# Altium schematic sheet Power_Board_R1.SchDoc
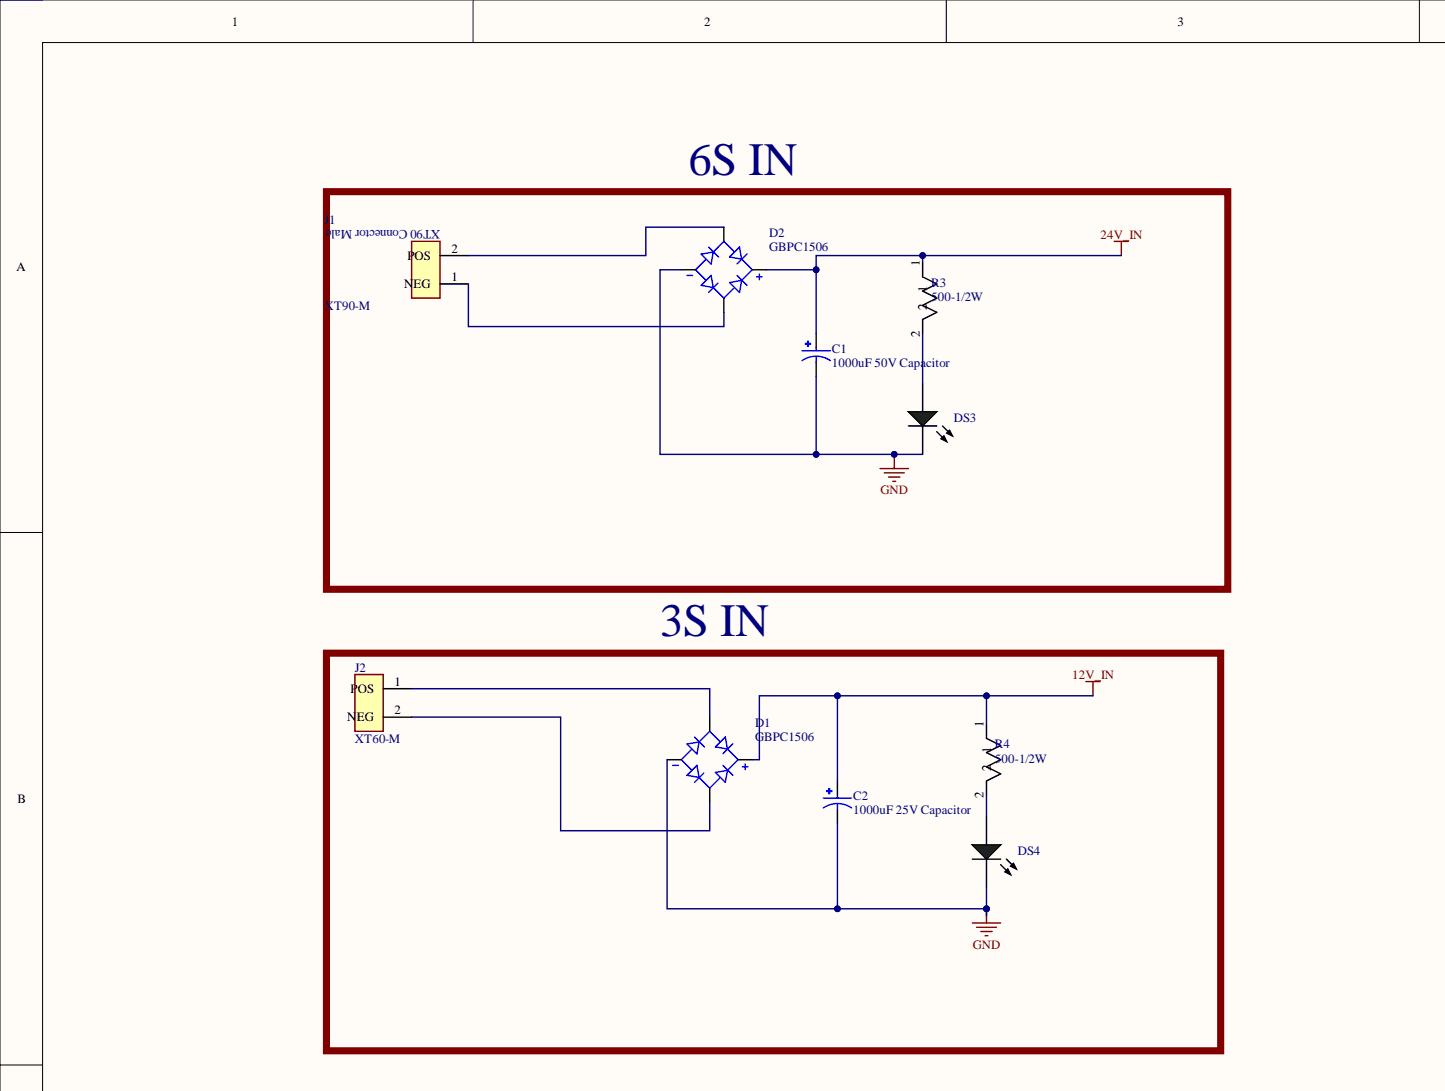
[diagram p0: Power_Board_R1.SchDoc, top left]
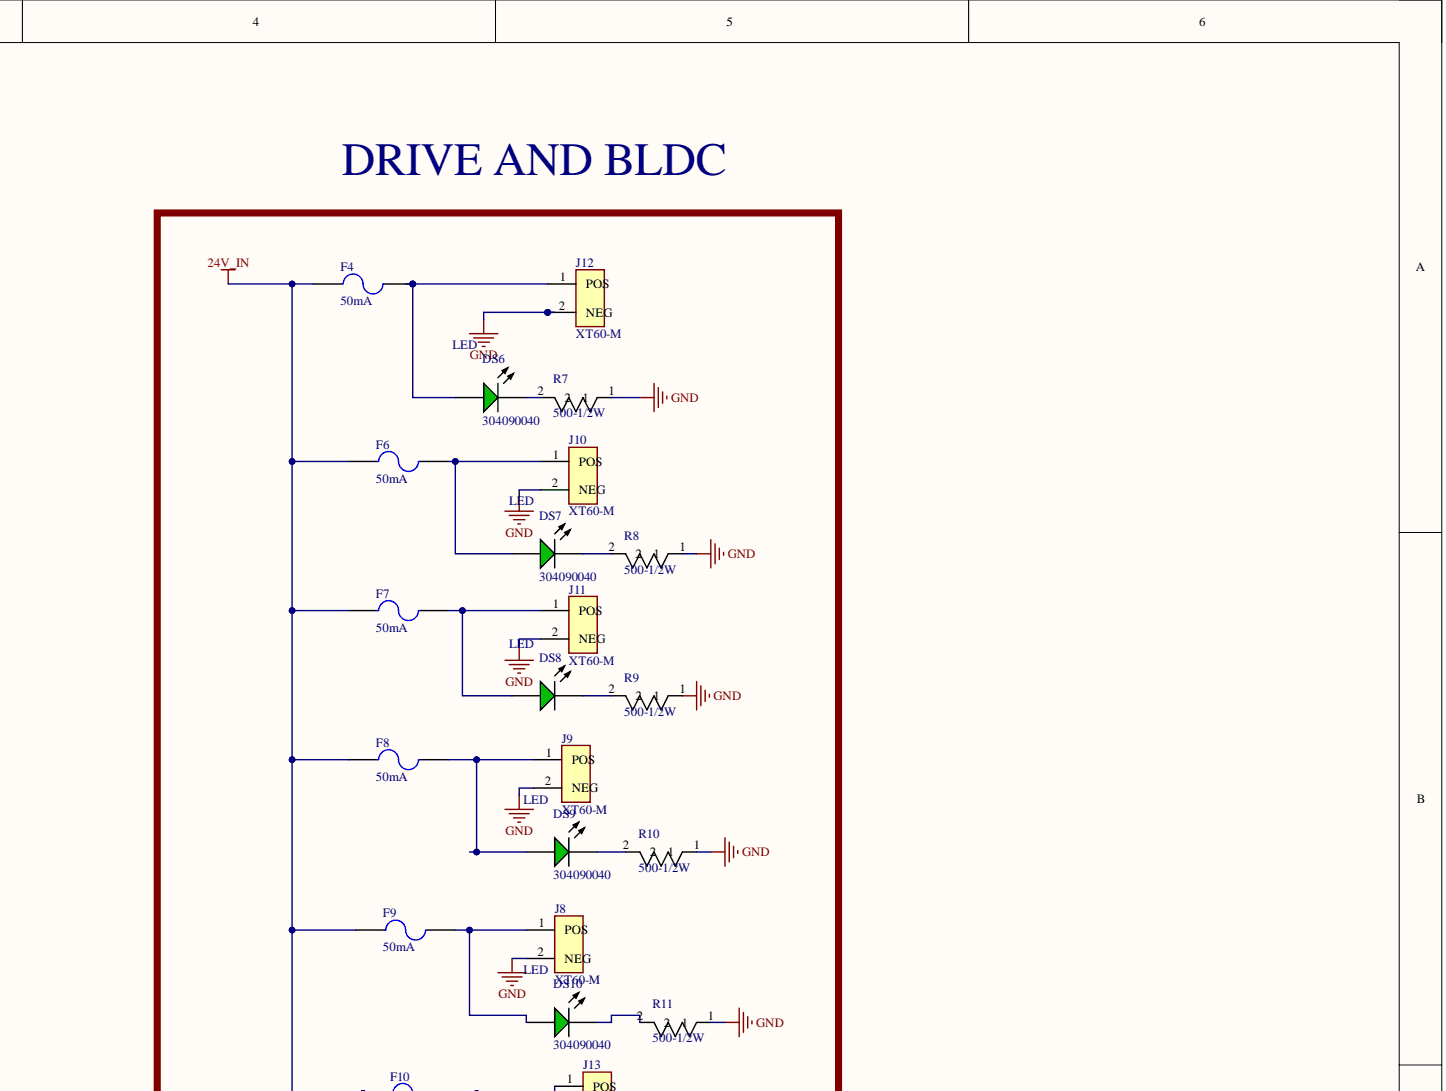
[diagram p1: Power_Board_R1.SchDoc, top right]
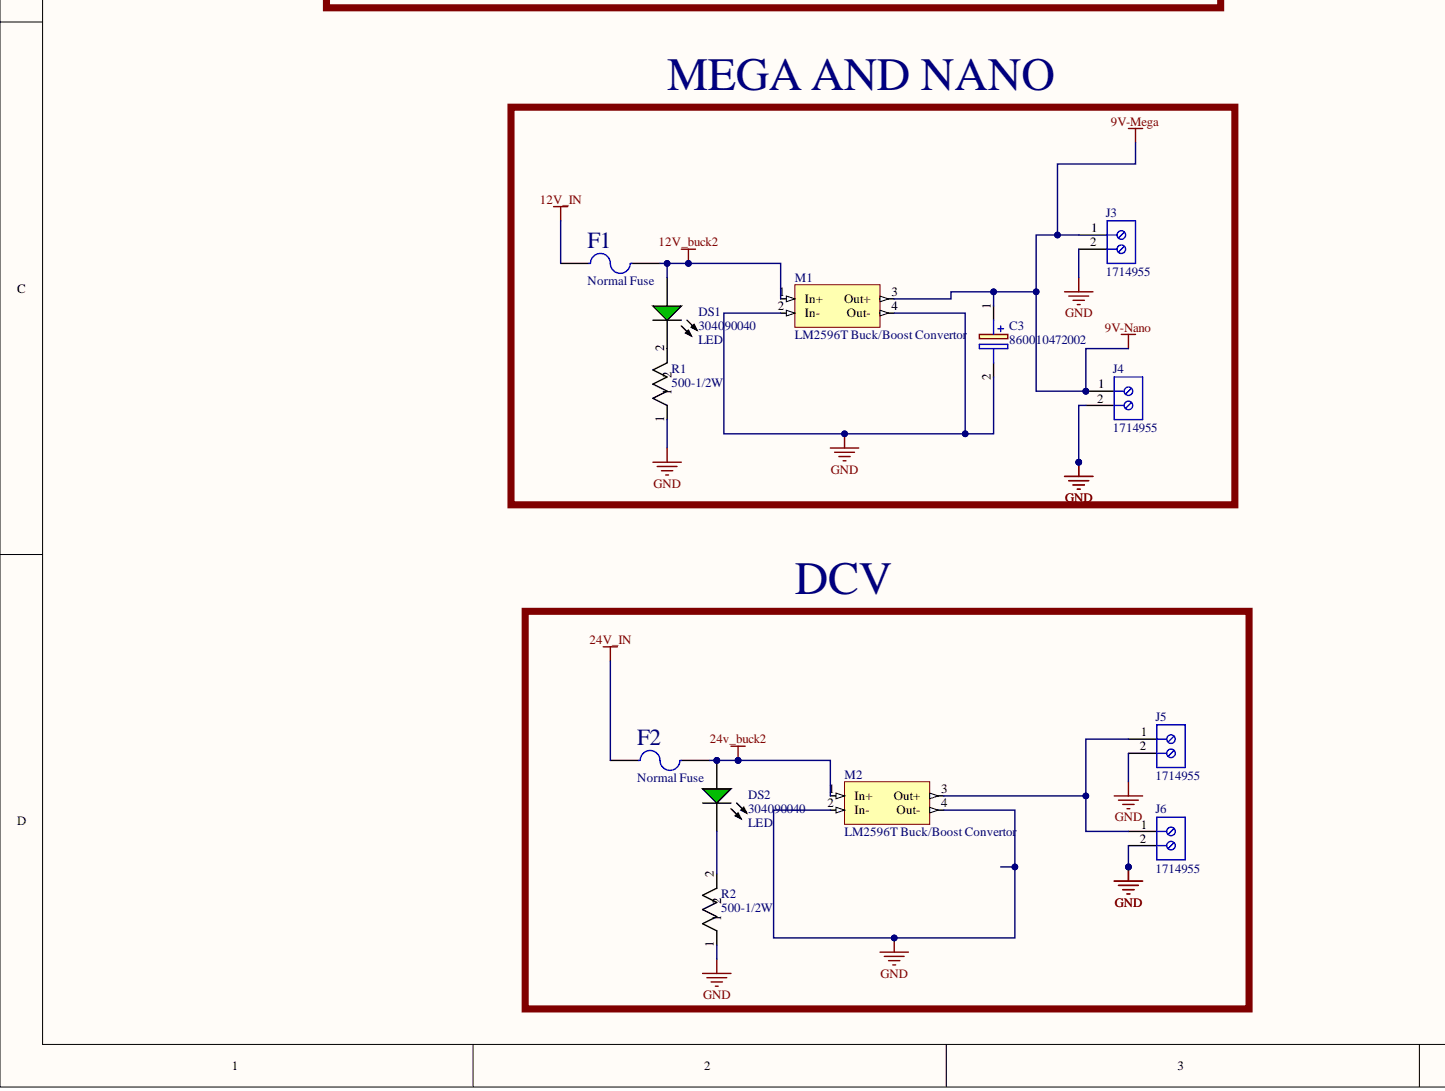
[diagram p2: Power_Board_R1.SchDoc, bottom left]
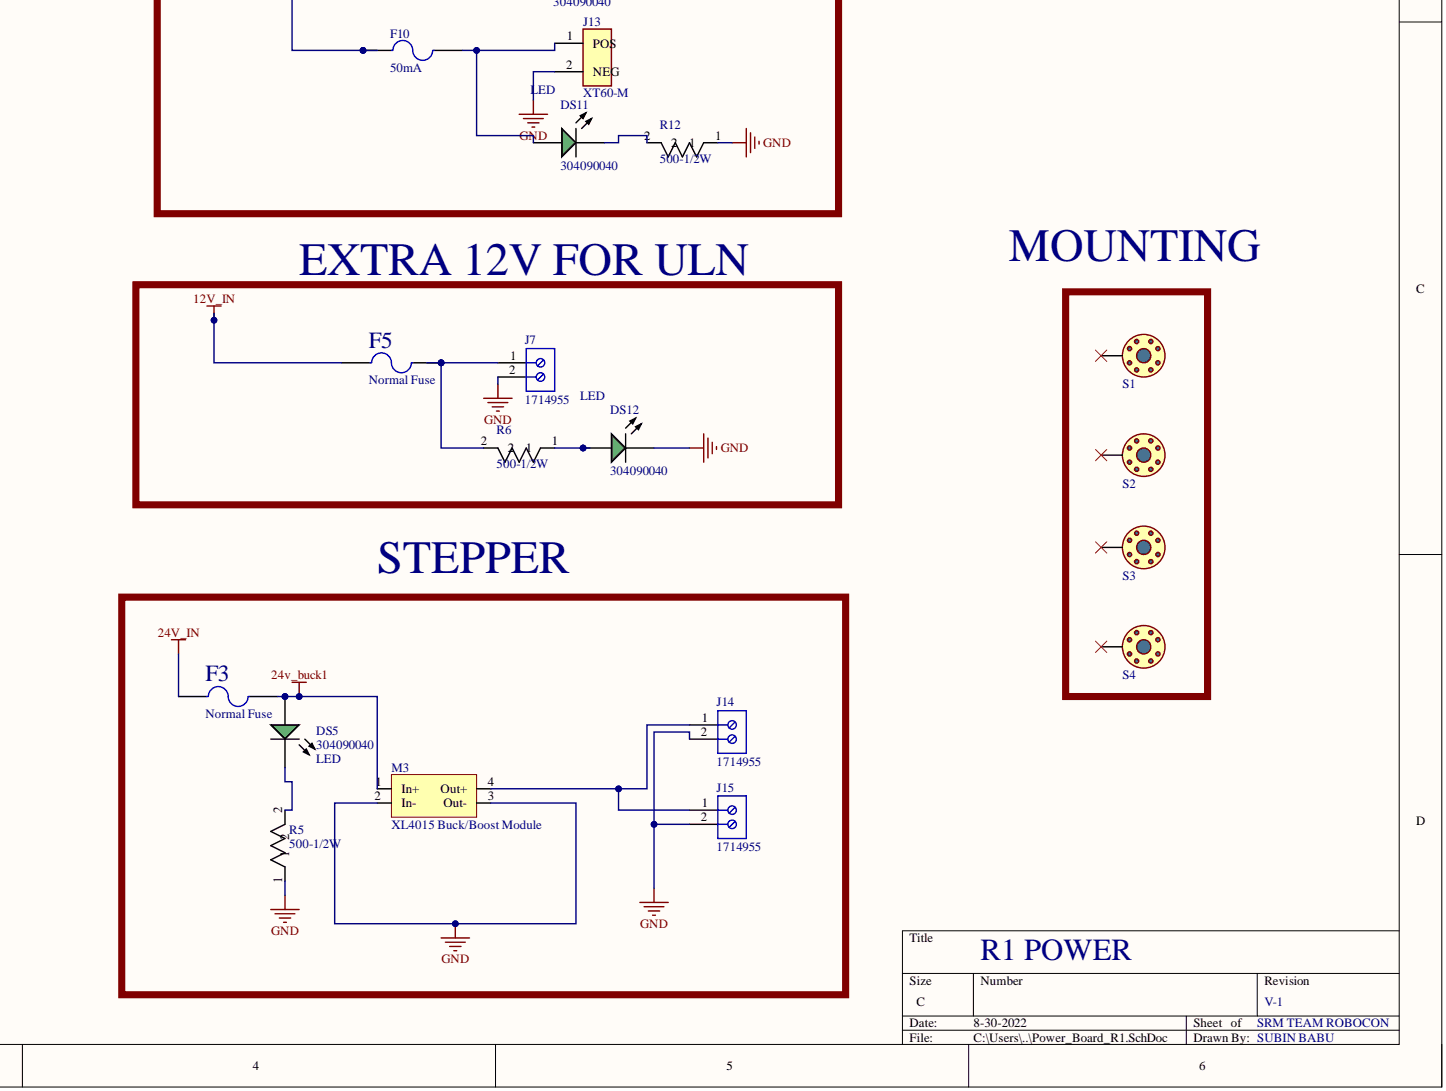
[diagram p3: Power_Board_R1.SchDoc, bottom right]

## Parts
C1  1000uF 50V Capacitor  [1000uF 50V Capacitor]
C2  1000uF 25V Capacitor  [1000uF 25V Capacitor]
C3  860010472002  [CAPPRD200W52D500H1250]
D1  GBPC1506  [DIOB_GBPC1506]
D2  GBPC1506  [DIOB_GBPC1506]
DS1  304090040  Component Type: LED  [LED-2P-2.28-3MM]
DS2  304090040  Component Type: LED  [LED-2P-2.28-3MM]
DS3  (no value)  [LED-2P-2.28-3MM]
DS4  (no value)  [LED-2P-2.28-3MM]
DS5  304090040  Component Type: LED  [LED-2P-2.28-3MM]
DS6  304090040  Component Type: LED  [LED-2P-2.28-3MM]
DS7  304090040  Component Type: LED  [LED-2P-2.28-3MM]
DS8  Component Type: LED  [LED-2P-2.28-3MM]
DS9  304090040  [LED-2P-2.28-3MM]
DS10  304090040  [LED-2P-2.28-3MM]
DS11  304090040  [LED-2P-2.28-3MM]
DS12  304090040  Component Type: LED  [LED-2P-2.28-3MM]
F1  Normal Fuse  [Fuse]
F2  Normal Fuse  [Fuse]
F3  Normal Fuse  [Fuse]
F4  50mA  [FUSE(ALL SIZE)]
F5  Normal Fuse  [Fuse]
F6  50mA  [FUSE(ALL SIZE)]
F7  50mA  [FUSE(ALL SIZE)]
F8  50mA  [FUSE(ALL SIZE)]
F9  50mA  [FUSE(ALL SIZE)]
F10  50mA  [FUSE(ALL SIZE)]
J1  XT90-M  [XT90-M]
J2  XT60-M  [XT-60M]
J3  1714955  [6.35mm 2 Way Screw Terminal]
J4  1714955  [6.35mm 2 Way Screw Terminal]
J5  1714955  [6.35mm 2 Way Screw Terminal]
J6  1714955  [6.35mm 2 Way Screw Terminal]
J7  1714955  [6.35mm 2 Way Screw Terminal]
J8  XT60-M  [XT-60M]
J9  XT60-M  [XT-60M]
J10  XT60-M  [XT-60M]
J11  XT60-M  [XT-60M]
J12  XT60-M  [XT-60M]
J13  XT60-M  [XT-60M]
J14  1714955  [6.35mm 2 Way Screw Terminal]
J15  1714955  [6.35mm 2 Way Screw Terminal]
M1  LM2596T Buck/Boost Convertor  [LM2596 MODULE]
M2  LM2596T Buck/Boost Convertor  [LM2596 MODULE]
M3  XL4015 Buck/Boost Module  [XL4015 Module]
R1  500-1/2W  [R_Axial_DIN0309_L9.0mm_D3.2mm_P12.70mm_Horizontal]
R2  500-1/2W  [R_Axial_DIN0309_L9.0mm_D3.2mm_P12.70mm_Horizontal]
R3  500-1/2W  [R_Axial_DIN0309_L9.0mm_D3.2mm_P12.70mm_Horizontal]
R4  500-1/2W  [R_Axial_DIN0309_L9.0mm_D3.2mm_P12.70mm_Horizontal]
R5  500-1/2W  [R_Axial_DIN0309_L9.0mm_D3.2mm_P12.70mm_Horizontal]
R6  500-1/2W  [R_Axial_DIN0309_L9.0mm_D3.2mm_P12.70mm_Horizontal]
R7  500-1/2W  [R_Axial_DIN0309_L9.0mm_D3.2mm_P12.70mm_Horizontal]
R8  500-1/2W  [R_Axial_DIN0309_L9.0mm_D3.2mm_P12.70mm_Horizontal]
R9  500-1/2W  [R_Axial_DIN0309_L9.0mm_D3.2mm_P12.70mm_Horizontal]
R10  500-1/2W  [R_Axial_DIN0309_L9.0mm_D3.2mm_P12.70mm_Horizontal]
R11  500-1/2W  [R_Axial_DIN0309_L9.0mm_D3.2mm_P12.70mm_Horizontal]
R12  500-1/2W  [R_Axial_DIN0309_L9.0mm_D3.2mm_P12.70mm_Horizontal]
S1  Screw  [SCREW-3MM]  (value not drawn)
S2  Screw  [SCREW-3MM]  (value not drawn)
S3  Screw  [SCREW-3MM]  (value not drawn)
S4  Screw  [SCREW-3MM]  (value not drawn)

## Nets
9V-Mega: C3.1 J3.1 J4.1 M1.3(Out+)
12V_buck2: DS1.1 F1.2 M1.1(In+)
12V_IN: C2.P D1.P F1.1 F5.1 R4.1
24v_buck1: DS5.1 F3.2 M3.1(In+)
24v_buck2: DS2.1 F2.2 M2.1(In+)
24V_IN: C1.P D2.P F2.1 F3.1 F4.2 F6.2 F7.2 F8.2 F9.2 F10.2 R3.1
GND: C1.N C2.N C3.2 D1.N D2.N DS3.2 DS4.2 DS12.2 J3.2 J4.2 J5.2 J6.2 J7.2 J8.2 J9.2 J10.2 J11.2 J12.2 J13.2 J14.2 J15.2 M1.2(In-) M1.4(Out-) M2.2(In-) M2.4(Out-) M3.2(In-) M3.3(Out-) R1.1 R2.1 R5.1 R7.1 R8.1 R9.1 R10.1 R11.1 R12.1
NetD1_AC1: D1.AC1 J2.2
NetD1_AC2: D1.AC2 J2.1
NetD2_AC1: D2.AC1 J1.1
NetD2_AC2: D2.AC2 J1.2
NetDS1_2: DS1.2 R1.2
NetDS2_2: DS2.2 R2.2
NetDS3_1: DS3.1 R3.2
NetDS4_1: DS4.1 R4.2
NetDS5_2: DS5.2 R5.2
NetDS6_1: DS6.1 F4.1 J12.1
NetDS6_2: DS6.2 R7.2
NetDS7_1: DS7.1 F6.1 J10.1
NetDS7_2: DS7.2 R8.2
NetDS8_1: DS8.1 F7.1 J11.1
NetDS8_2: DS8.2 R9.2
NetDS9_1: DS9.1 F8.1 J9.1
NetDS9_2: DS9.2 R10.2
NetDS10_1: DS10.1 F9.1 J8.1
NetDS10_2: DS10.2 R11.2
NetDS11_1: DS11.1 F10.1 J13.1
NetDS11_2: DS11.2 R12.2
NetDS12_1: DS12.1 R6.1
NetF5_2: F5.2 J7.1 R6.2
NetJ5_1: J5.1 J6.1 M2.3(Out+)
NetJ14_1: J14.1 J15.1 M3.4(Out+)

Not connected: S1 0; S2 0; S3 0; S4 0

## Library data of the parts
C1 = 1000uF 50V Capacitor: CAP ALUM 1000UF 20% 50V RADIAL; MF: Panasonic; MP: EEU-HD1H102B; Availability: In Stock; Check_prices: https://www.snapeda.com/parts/EEU-HD1H102B/Panasonic/view-part/2335470/?ref=eda; Component Kind: Standard; Library Name: R1.SchLib; Package: RADIAL-2 Panasonic; Price: None; SnapEDA_Link: https://www.snapeda.com/parts/EEU-HD1H102B/Panasonic/view-part/2335470/?ref=snap
C2 = 1000uF 25V Capacitor: CAP ALUM 1000UF 20% 25V RADIAL; MF: Panasonic; MP: EEUTA1E102LB; Availability: No availability data; Check_prices: https://www.snapeda.com/parts/EEUTA1E102LB/Panasonic/view-part/5789306/?ref=eda; Component Kind: Standard; Library Name: R1.SchLib; Package: RADIAL-2 Panasonic; Price: None; SnapEDA_Link: https://www.snapeda.com/parts/EEUTA1E102LB/Panasonic/view-part/5789306/?ref=snap
C3 = 860010472002: Capacitor Polarised; Manufacturer_Name: Wurth Elektronik; Component Kind: Standard; Datasheet Link: https://www.we-online.com/catalog/datasheet/860010472002.pdf; Height: 12.5mm; Manufacturer_Part_Number: 860010472002; Mouser Part Number: 710-860010472002; Mouser Price/Stock: https://www.mouser.co.uk/ProductDetail/Wurth-Elektronik/860010472002?qs=0KOYDY2FL2%2FyXlauDHcLjg%3D%3D
D1 D2 = GBPC1506: Bridge Rectifier Single Phase Standard 600 V QC Terminal GBPC; MF: ON Semiconductor; Availability: In Stock; Check_prices: https://www.snapeda.com/parts/GBPC1506/Onsemi/view-part/?ref=eda; Component Kind: Standard; Library Name: R1.SchLib; MP: GBPC1506; Package: GBPC-4 ON Semiconductor; Price: None; Purchase-URL: https://pricing.snapeda.com/search/part/GBPC1506/?ref=eda; SnapEDA_Link: https://www.snapeda.com/parts/GBPC1506/Onsemi/view-part/?ref=snap
DS1-DS12 = CMP-007-00006-1: Green LED, 80 mW, 20 mA, -25 to 85 degC, 2-Pin THD; Manufacturer: Shenzhen CGX; Case/Package: 304090040; Component Kind: Standard; Datasheet URL: http://datasheet.octopart.com/304090040-Chengguangxing-datasheet-62330655.pdf; Datasheet Version: Rev. 1.0, 09/2014; Library Name: R1.SchLib; Manufacturer URL: http://szcgx.com/; Mounting Technology: TH; Package Description: 2-Pin Through Hole Device, Dia 3 mm, Pitch 2.28 mm; Package Reference: 304090040; RoHS Compliant: Unknown
  DS9-DS11: Component Type: LED
F1-F3 F5 = Fuse_1: Component Kind: Standard; Library Name: R1.SchLib
F4 F6-F10 = Fuse: Component Kind: Standard; Library Name: R1.SchLib
J2 J8-J13 = XT60-M: Plug; DC supply; XT60; male; PIN: 2; for cable; soldered; 30A; 500V; MF: AMASS; Availability: Unavailable; Component Kind: Standard; Library Name: R1.SchLib; MP: XT60-M; Package: Package Analog Devices; Price: None; SnapEDA_Link: https://www.snapeda.com/parts/XT60-M/AMASS/view-part/2883912/?ref=snap
J3-J7 J14 J15 = 6.35mm 2 Way Screw Terminal: PC Terminal Block, Pitch 6.35 mm, 1 x 2 Position, Height 21.5 mm, Tail Lenght 5.1 mm, RoHS, Bulk; Manufacturer: Phoenix Contact; Component Kind: Standard; Datasheet URL: http://media.digikey.com/pdf/Data%20Sheets/Phoenix%20Contact%20PDFs/1714955.pdf; Datasheet Version: 02/2010; Library Name: R1.SchLib; Manufacturer URL: http://www.phoenixcontact.com/; Mounting Technology: ThroughHole; Package Description: PC Terminal Block, Pitch 6.35 mm, 1 x 2 Position, Height 21.5 mm, Tail Lenght 5.1 mm; Package Reference: 1714955
R1-R12 = 1/2 watt Resistor: Component Kind: Standard; Library Name: R1.SchLib
S1-S4 = Screw: 3mm Screw; ASiD Part Number: Screw-AS; Component Kind: Net Tie; Library Name: R1.SchLib
J1 = XT90-M: Component Kind: Standard; Library Name: R1.SchLib
M1 M2 = LM2596T Buck/Boost Convertor: Component Kind: Standard; Library Name: R1.SchLib
M3 = XL4015 Buck/Boost Module: Component Kind: Standard; Library Name: R1.SchLib
